# Altium schematic sheet USB_PHY.SchDoc
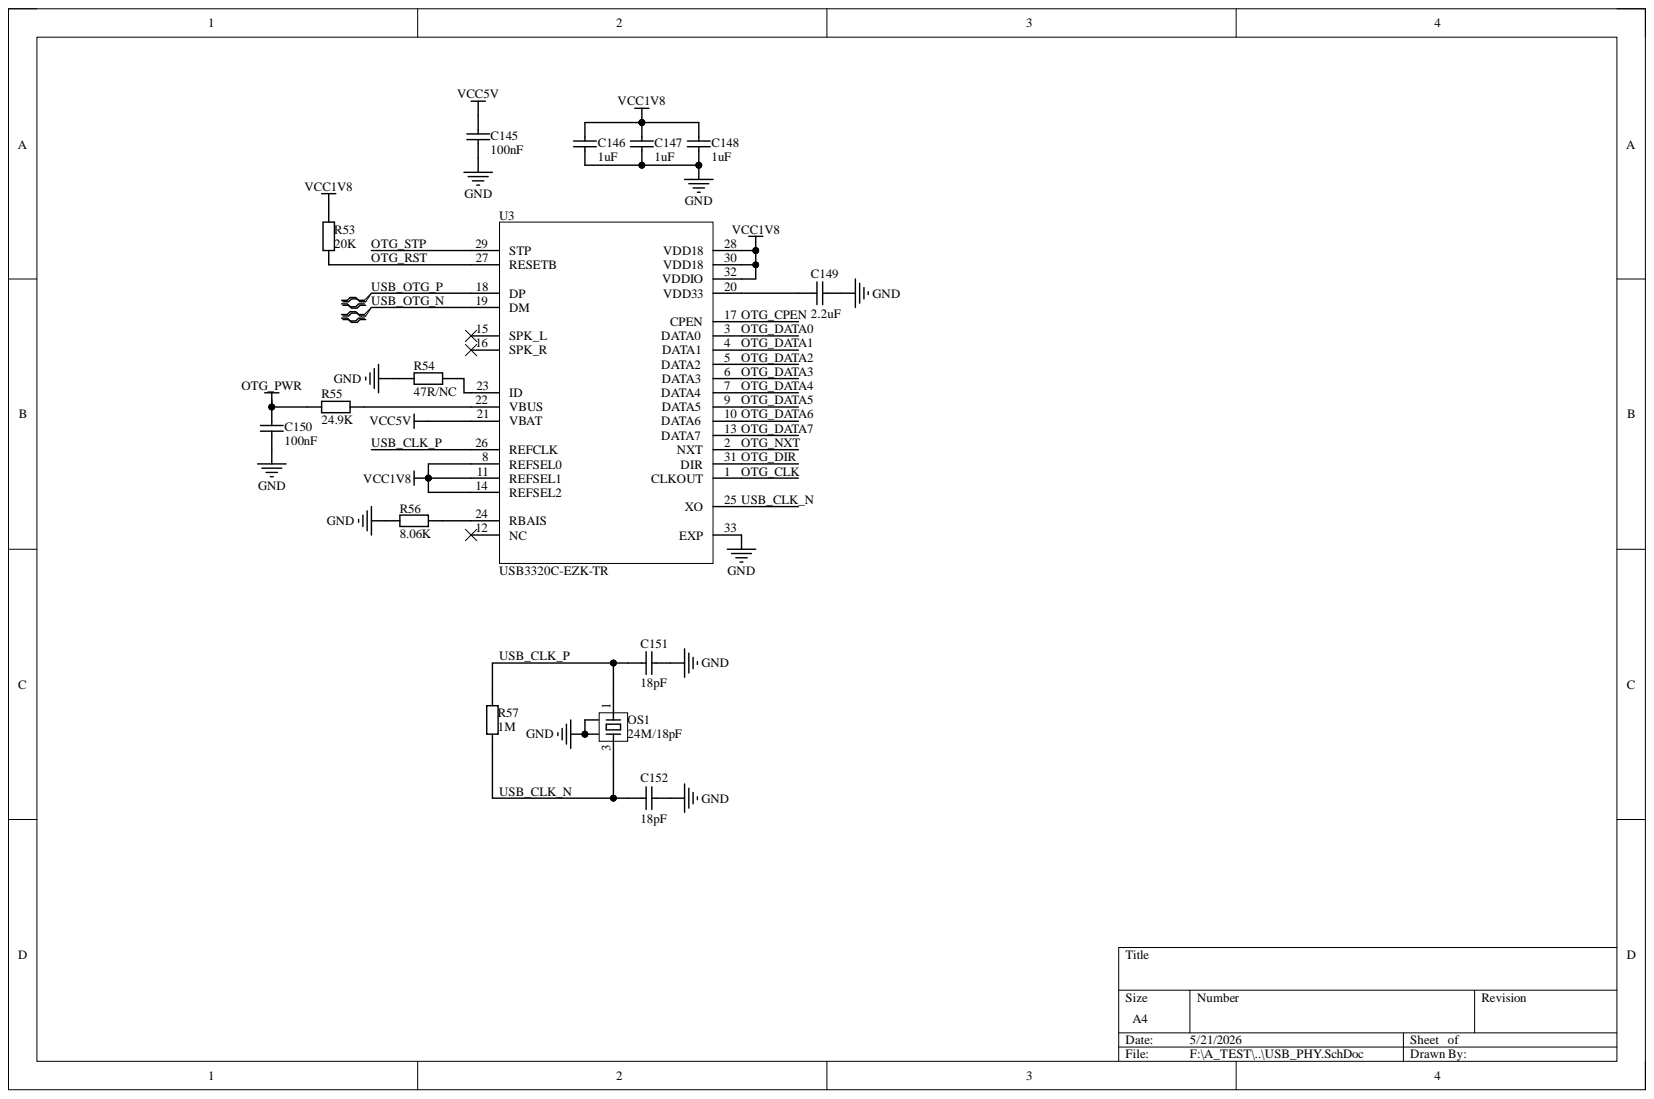
[diagram p0: USB_PHY.SchDoc]

## Parts
C145  100nF  [C 0402_L]
C146  1uF  [C 0402_L]
C147  1uF  [C 0402_L]
C148  1uF  [C 0402_L]
C149  2.2uF  [C 0603_L]
C150  100nF  [C 0402_L]
C151  18pF  [C 0402_L]
C152  18pF  [C 0402_L]
OS1  24M/18pF  [OSC 3225-4P]
R53  20K  [R 0402_L]
R54  47R/NC  [R 0402_L]
R55  24.9K  [R 0402_L]
R56  8.06K  [R 0402_L]
R57  1M  [R 0402_L]
U3  USB3320C-EZK-TR  [QFN50P500X500X100-33N-D]

## Nets
GND: C145.1 C146.1 C147.1 C148.1 C149.2 C150.1 C151.2 C152.2 OS1.2 OS1.4 R54.1 R56.1 U3.33(EXP)
OTG_PWR: C150.2 R55.1
VCC1V8: C146.2 C147.2 C148.2 R53.2 U3.8(REFSEL0) U3.11(REFSEL1) U3.14(REFSEL2) U3.28(VDD18) U3.30(VDD18) U3.32(VDDIO)
VCC5V: C145.2 U3.21(VBAT)
OTG_CLK: U3.1(CLKOUT)
OTG_CPEN: U3.17(CPEN)
OTG_DATA0: U3.3(DATA0)
OTG_DATA1: U3.4(DATA1)
OTG_DATA2: U3.5(DATA2)
OTG_DATA3: U3.6(DATA3)
OTG_DATA4: U3.7(DATA4)
OTG_DATA5: U3.9(DATA5)
OTG_DATA6: U3.10(DATA6)
OTG_DATA7: U3.13(DATA7)
OTG_DIR: U3.31(DIR)
OTG_NXT: U3.2(NXT)
OTG_RST: R53.1 U3.27(RESETB)
OTG_STP: U3.29(STP)
USB_CLK_N: C152.1 OS1.3 R57.1 U3.25(XO)
USB_CLK_P: C151.1 OS1.1 R57.2 U3.26(REFCLK)
USB_OTG_N: U3.19(DM)
USB_OTG_P: U3.18(DP)
NetC149_1: C149.1 U3.20(VDD33)
NetR54_2: R54.2 U3.23(ID)
NetR55_2: R55.2 U3.22(VBUS)
NetR56_2: R56.2 U3.24(RBAIS)

Not connected: U3 12(NC) 15(SPK_L) 16(SPK_R)

## Library data of the parts
C145-C150 = Cap: 贴片电容; Component Kind: Standard; Library Name: A_Schlib.SchLib
  C145 C150: value: 100nF
  C146-C148: value: 1uF
  C149: value: 2.2uF
C151 C152 = Cap1: Component Kind: Standard; value: 18pF; Library Name: 零散元件库.SCHLIB
OS1 = XTAL-4P: 4脚无源晶振; 版权归属: 封装库来自淘宝卖家 ID：黄晶宝石; BOM名称: 4脚无源晶振; Component Kind: Standard; Library Name: A_Schlib.SchLib; 学习资源: https://shop463601444.taobao.com
R53-R57 = Res: 通用; Component Kind: Standard; Library Name: A_Schlib.SchLib
  R53: value: 20K
  R54: value: 47R/NC
  R55: value: 24.9K
  R56: value: 8.06K
  R57: value: 1M
U3 = USB3320C-EZK-TR: USB-PHY芯片; Component Kind: Standard; Library Name: A_Schlib.SchLib
